# Altium schematic sheet w_ergolite_left_matrix.SchDoc
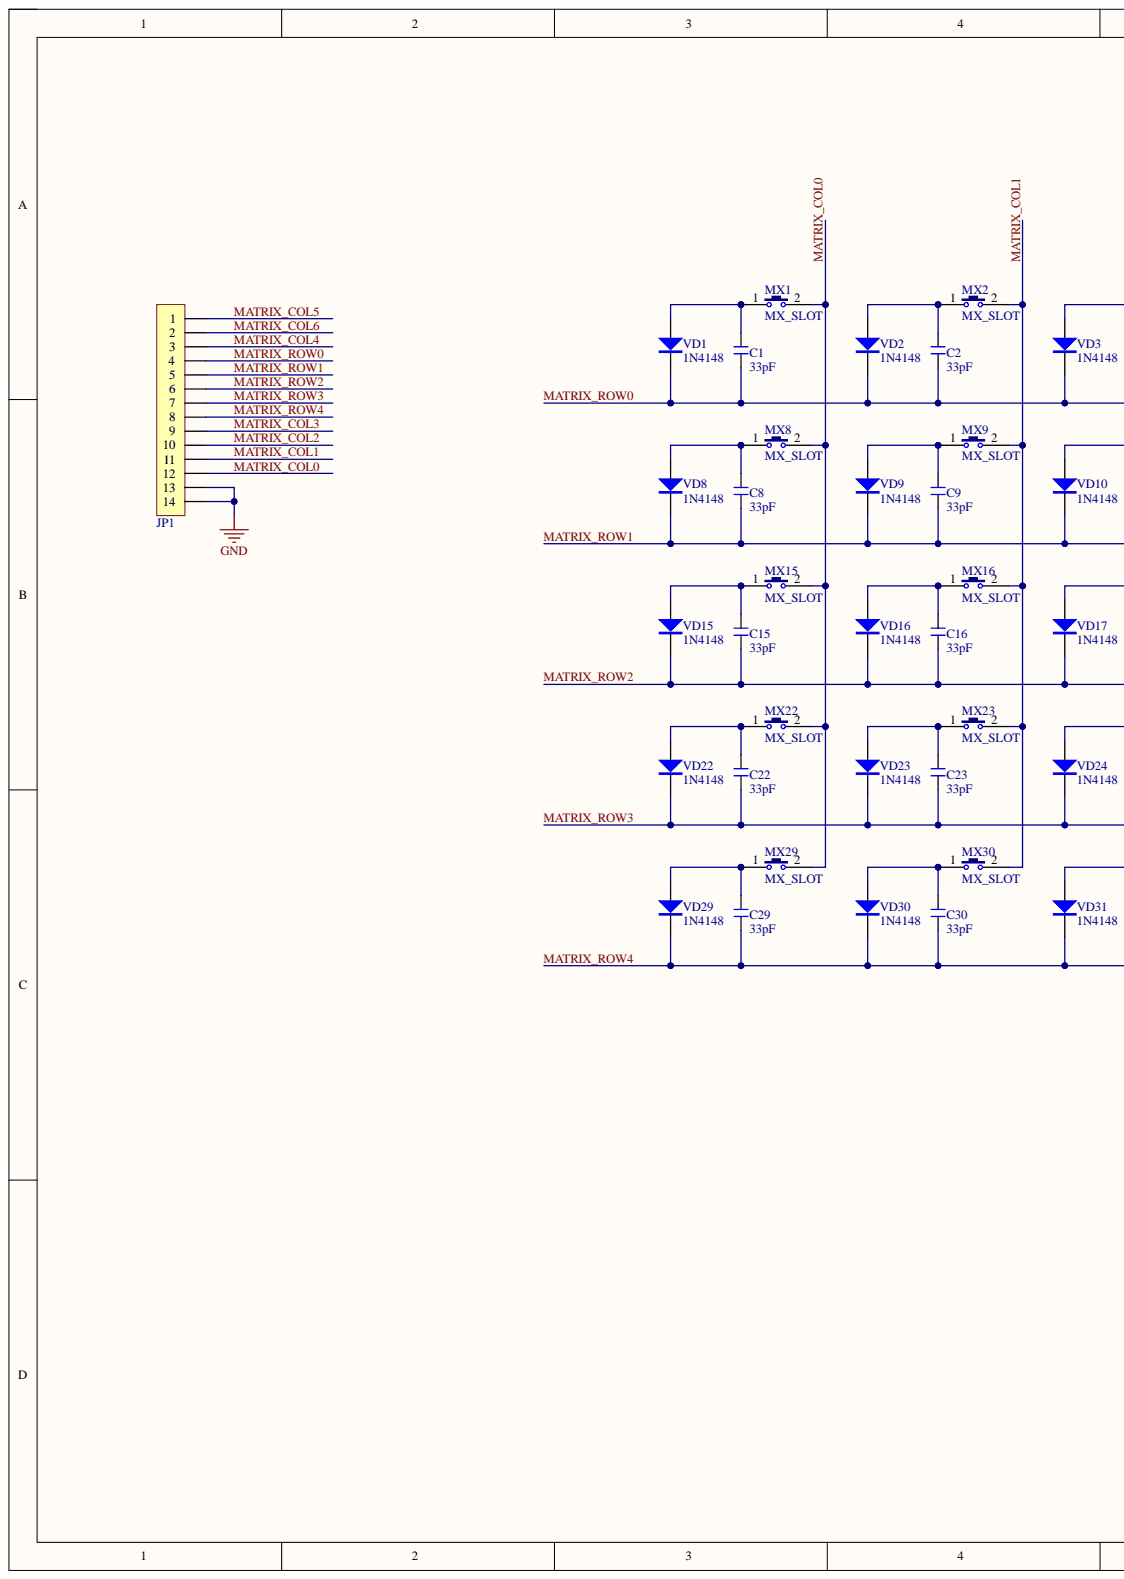
[diagram p0: w_ergolite_left_matrix.SchDoc, left]
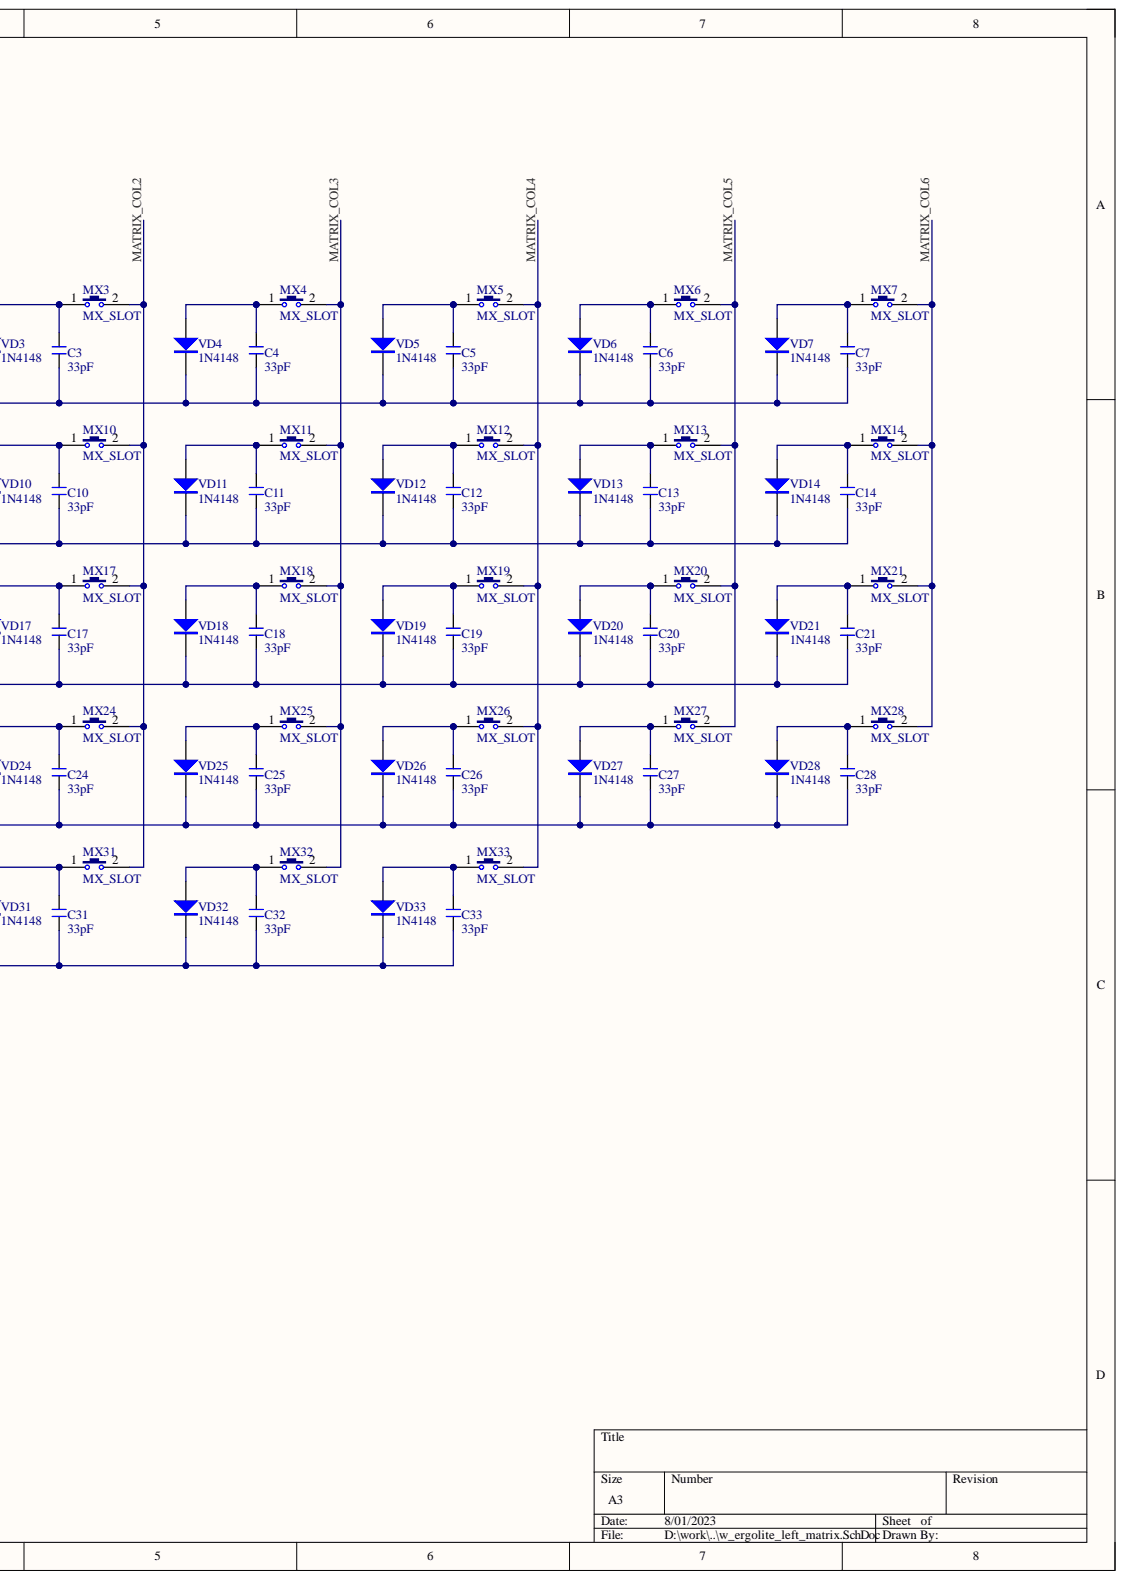
[diagram p1: w_ergolite_left_matrix.SchDoc, right]

## Parts
C1  33pF  [C 0402]
C2  33pF  [C 0402]
C3  33pF  [C 0402]
C4  33pF  [C 0402]
C5  33pF  [C 0402]
C6  33pF  [C 0402]
C7  33pF  [C 0402]
C8  33pF  [C 0402]
C9  33pF  [C 0402]
C10  33pF  [C 0402]
C11  33pF  [C 0402]
C12  33pF  [C 0402]
C13  33pF  [C 0402]
C14  33pF  [C 0402]
C15  33pF  [C 0402]
C16  33pF  [C 0402]
C17  33pF  [C 0402]
C18  33pF  [C 0402]
C19  33pF  [C 0402]
C20  33pF  [C 0402]
C21  33pF  [C 0402]
C22  33pF  [C 0402]
C23  33pF  [C 0402]
C24  33pF  [C 0402]
C25  33pF  [C 0402]
C26  33pF  [C 0402]
C27  33pF  [C 0402]
C28  33pF  [C 0402]
C29  33pF  [C 0402]
C30  33pF  [C 0402]
C31  33pF  [C 0402]
C32  33pF  [C 0402]
C33  33pF  [C 0402]
JP1  FPC1.0-14P  [FPC0.5 2H-S-W-14P]  (value not drawn)
MX1  MX_SLOT  [GATERON_LOW_PROFILE_AND_NORMAL]
MX2  MX_SLOT  [GATERON_LOW_PROFILE_AND_NORMAL]
MX3  MX_SLOT  [GATERON_LOW_PROFILE_AND_NORMAL]
MX4  MX_SLOT  [GATERON_LOW_PROFILE_AND_NORMAL]
MX5  MX_SLOT  [GATERON_LOW_PROFILE_AND_NORMAL]
MX6  MX_SLOT  [GATERON_LOW_PROFILE_AND_NORMAL]
MX7  MX_SLOT  [GATERON_LOW_PROFILE_AND_NORMAL]
MX8  MX_SLOT  [GATERON_LOW_PROFILE_AND_NORMAL]
MX9  MX_SLOT  [GATERON_LOW_PROFILE_AND_NORMAL]
MX10  MX_SLOT  [GATERON_LOW_PROFILE_AND_NORMAL]
MX11  MX_SLOT  [GATERON_LOW_PROFILE_AND_NORMAL]
MX12  MX_SLOT  [GATERON_LOW_PROFILE_AND_NORMAL]
MX13  MX_SLOT  [GATERON_LOW_PROFILE_AND_NORMAL]
MX14  MX_SLOT  [GATERON_LOW_PROFILE_AND_NORMAL]
MX15  MX_SLOT  [GATERON_LOW_PROFILE_AND_NORMAL]
MX16  MX_SLOT  [GATERON_LOW_PROFILE_AND_NORMAL]
MX17  MX_SLOT  [GATERON_LOW_PROFILE_AND_NORMAL]
MX18  MX_SLOT  [GATERON_LOW_PROFILE_AND_NORMAL]
MX19  MX_SLOT  [GATERON_LOW_PROFILE_AND_NORMAL]
MX20  MX_SLOT  [GATERON_LOW_PROFILE_AND_NORMAL]
MX21  MX_SLOT  [GATERON_LOW_PROFILE_AND_NORMAL]
MX22  MX_SLOT  [GATERON_LOW_PROFILE_AND_NORMAL]
MX23  MX_SLOT  [GATERON_LOW_PROFILE_AND_NORMAL]
MX24  MX_SLOT  [GATERON_LOW_PROFILE_AND_NORMAL]
MX25  MX_SLOT  [GATERON_LOW_PROFILE_AND_NORMAL]
MX26  MX_SLOT  [GATERON_LOW_PROFILE_AND_NORMAL]
MX27  MX_SLOT  [GATERON_LOW_PROFILE_AND_NORMAL]
MX28  MX_SLOT  [GATERON_LOW_PROFILE_AND_NORMAL]
MX29  MX_SLOT  [GATERON_LOW_PROFILE_AND_NORMAL]
MX30  MX_SLOT  [GATERON_LOW_PROFILE_AND_NORMAL]
MX31  MX_SLOT  [GATERON_LOW_PROFILE_AND_NORMAL]
MX32  MX_SLOT  [GATERON_LOW_PROFILE_AND_NORMAL]
MX33  MX_SLOT  [GATERON_LOW_PROFILE_AND_NORMAL]
VD1  1N4148  [SOD-323]
VD2  1N4148  [SOD-323]
VD3  1N4148  [SOD-323]
VD4  1N4148  [SOD-323]
VD5  1N4148  [SOD-323]
VD6  1N4148  [SOD-323]
VD7  1N4148  [SOD-323]
VD8  1N4148  [SOD-323]
VD9  1N4148  [SOD-323]
VD10  1N4148  [SOD-323]
VD11  1N4148  [SOD-323]
VD12  1N4148  [SOD-323]
VD13  1N4148  [SOD-323]
VD14  1N4148  [SOD-323]
VD15  1N4148  [SOD-323]
VD16  1N4148  [SOD-323]
VD17  1N4148  [SOD-323]
VD18  1N4148  [SOD-323]
VD19  1N4148  [SOD-323]
VD20  1N4148  [SOD-323]
VD21  1N4148  [SOD-323]
VD22  1N4148  [SOD-323]
VD23  1N4148  [SOD-323]
VD24  1N4148  [SOD-323]
VD25  1N4148  [SOD-323]
VD26  1N4148  [SOD-323]
VD27  1N4148  [SOD-323]
VD28  1N4148  [SOD-323]
VD29  1N4148  [SOD-323]
VD30  1N4148  [SOD-323]
VD31  1N4148  [SOD-323]
VD32  1N4148  [SOD-323]
VD33  1N4148  [SOD-323]

## Nets
GND: JP1.13 JP1.14
MATRIX_COL0: JP1.12 MX1.2 MX8.2 MX15.2 MX22.2 MX29.2
MATRIX_COL1: JP1.11 MX2.2 MX9.2 MX16.2 MX23.2 MX30.2
MATRIX_COL2: JP1.10 MX3.2 MX10.2 MX17.2 MX24.2 MX31.2
MATRIX_COL3: JP1.9 MX4.2 MX11.2 MX18.2 MX25.2 MX32.2
MATRIX_COL4: JP1.3 MX5.2 MX12.2 MX19.2 MX26.2 MX33.2
MATRIX_COL5: JP1.1 MX6.2 MX13.2 MX20.2 MX27.2
MATRIX_COL6: JP1.2 MX7.2 MX14.2 MX21.2 MX28.2
MATRIX_ROW0: C1.1 C2.1 C3.1 C4.1 C5.1 C6.1 C7.1 JP1.4 VD1.2 VD2.2 VD3.2 VD4.2 VD5.2 VD6.2 VD7.2
MATRIX_ROW1: C8.1 C9.1 C10.1 C11.1 C12.1 C13.1 C14.1 JP1.5 VD8.2 VD9.2 VD10.2 VD11.2 VD12.2 VD13.2 VD14.2
MATRIX_ROW2: C15.1 C16.1 C17.1 C18.1 C19.1 C20.1 C21.1 JP1.6 VD15.2 VD16.2 VD17.2 VD18.2 VD19.2 VD20.2 VD21.2
MATRIX_ROW3: C22.1 C23.1 C24.1 C25.1 C26.1 C27.1 C28.1 JP1.7 VD22.2 VD23.2 VD24.2 VD25.2 VD26.2 VD27.2 VD28.2
MATRIX_ROW4: C29.1 C30.1 C31.1 C32.1 C33.1 JP1.8 VD29.2 VD30.2 VD31.2 VD32.2 VD33.2
NetC1_2: C1.2 MX1.1 VD1.1
NetC2_2: C2.2 MX2.1 VD2.1
NetC3_2: C3.2 MX3.1 VD3.1
NetC4_2: C4.2 MX4.1 VD4.1
NetC5_2: C5.2 MX5.1 VD5.1
NetC6_2: C6.2 MX6.1 VD6.1
NetC7_2: C7.2 MX7.1 VD7.1
NetC8_2: C8.2 MX8.1 VD8.1
NetC9_2: C9.2 MX9.1 VD9.1
NetC10_2: C10.2 MX10.1 VD10.1
NetC11_2: C11.2 MX11.1 VD11.1
NetC12_2: C12.2 MX12.1 VD12.1
NetC13_2: C13.2 MX13.1 VD13.1
NetC14_2: C14.2 MX14.1 VD14.1
NetC15_2: C15.2 MX15.1 VD15.1
NetC16_2: C16.2 MX16.1 VD16.1
NetC17_2: C17.2 MX17.1 VD17.1
NetC18_2: C18.2 MX18.1 VD18.1
NetC19_2: C19.2 MX19.1 VD19.1
NetC20_2: C20.2 MX20.1 VD20.1
NetC21_2: C21.2 MX21.1 VD21.1
NetC22_2: C22.2 MX22.1 VD22.1
NetC23_2: C23.2 MX23.1 VD23.1
NetC24_2: C24.2 MX24.1 VD24.1
NetC25_2: C25.2 MX25.1 VD25.1
NetC26_2: C26.2 MX26.1 VD26.1
NetC27_2: C27.2 MX27.1 VD27.1
NetC28_2: C28.2 MX28.1 VD28.1
NetC29_2: C29.2 MX29.1 VD29.1
NetC30_2: C30.2 MX30.1 VD30.1
NetC31_2: C31.2 MX31.1 VD31.1
NetC32_2: C32.2 MX32.1 VD32.1
NetC33_2: C33.2 MX33.1 VD33.1

## Library data of the parts
C1-C33 = C: 无极性贴片电容; 版权归属: 源创客; BOM名称: 无极性贴片电容; Component Kind: Standard; Library Name: 电阻-电容-电感.SchLib; 学习资源: www.ooocke.com
JP1 = FPC-14P: FPC连接器; LC: C262663; 版权归属: 源创客; BOM名称: FPC连接器; Component Kind: Standard; Library Name: FPC连接器.SchLib; 学习资源: www.ooocke.com
MX1-MX33 = GATERON_LOW_PROFILE_AND_NORMAL: GATERON佳佳达隆矮轴复合普通轴热插拔轴座; Component Kind: Standard; Library Name: Connector.SchLib
VD1-VD33 = 1N4148: 高速开关二极管; 版权归属: 源创客; BOM名称: 高速开关二极管; Component Kind: Standard; Library Name: 二极管-整流桥.SchLib; 学习资源: www.ooocke.com
